# Altium schematic sheet Hall_sensors.SchDoc(Hall_sensors)
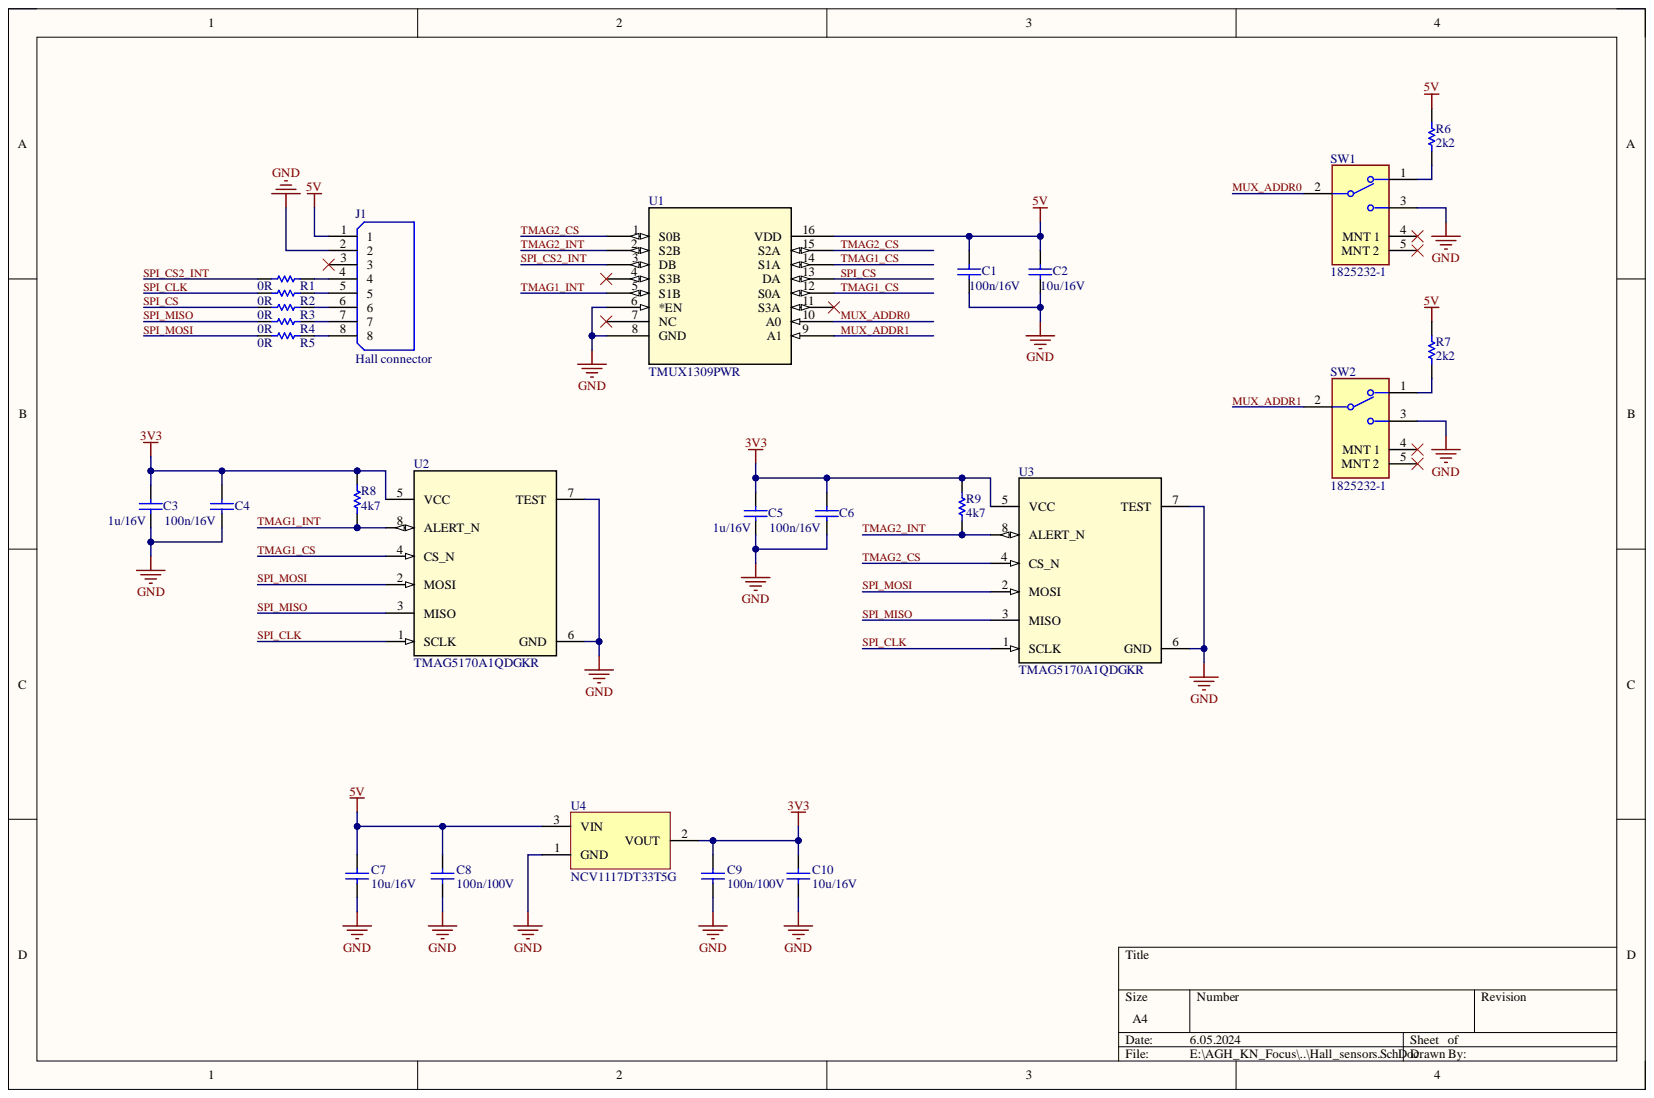
[diagram p0: Hall_sensors.SchDoc]

## Parts
C1  value 100n/16V  [CAP_0805N]  (hidden comment: 100n/100V/0805)
C2  value 10u/16V  [CAP_0805N]  (hidden comment: 10u/16V/0805)
C3  value 1u/16V  [CAP_0805N]  (hidden comment: 100n/100V/0805)
C4  value 100n/16V  [CAP_0805N]  (hidden comment: 10u/16V/0805)
C5  value 1u/16V  [CAP_0805N]  (hidden comment: 100n/100V/0805)
C6  value 100n/16V  [CAP_0805N]  (hidden comment: 10u/16V/0805)
C7  value 10u/16V  [CAP_0805N]  (hidden comment: 10u/16V/0805)
C8  value 100n/100V  [CAP_0805N]  (hidden comment: 100n/100V/0805)
C9  value 100n/100V  [CAP_0805N]  (hidden comment: 100n/100V/0805)
C10  value 10u/16V  [CAP_0805N]  (hidden comment: 10u/16V/0805)
J1  Hall connector  [AMPHENOL_10114830-11108LF]
R1  0R  [RESC1609X50X30NL8T20]
R2  0R  [RESC1609X50X30NL8T20]
R3  0R  [RESC1609X50X30NL8T20]
R4  0R  [RESC1609X50X30NL8T20]
R5  0R  [RESC1609X50X30NL8T20]
R6  2k2  [RESC2013X50X30LL20T20]
R7  2k2  [RESC2013X50X30LL20T20]
R8  4k7  [RESC2013X50X30LL20T20]
R9  4k7  [RESC2013X50X30LL20T20]
SW1  1825232-1  [FP-1825232-1-MFG]
SW2  1825232-1  [FP-1825232-1-MFG]
U1  TMUX1309PWR  [TSSOP_1308PWR_TEX]
U2  TMAG5170A1QDGKR  [DGK0008A-IPC_C]
U3  TMAG5170A1QDGKR  [DGK0008A-IPC_C]
U4  NCV1117DT33T5G  [NCV1117DT33T5G]

## Nets
3V3: C3.2 C4.1 C5.2 C6.1 C9.2 C10.2 R8.1 R9.1 U2.5(VCC) U3.5(VCC) U4.2
5V: C1.2 C2.1 C7.2 C8.2 J1.1 R6.1 R7.1 U1.16(VDD) U4.3
GND: C1.1 C2.2 C3.1 C4.2 C5.1 C6.2 C7.1 C8.1 C9.1 C10.1 J1.2 SW1.3 SW2.3 U1.6(*EN) U1.8(GND) U2.6(GND) U2.7(TEST) U3.6(GND) U3.7(TEST) U4.1
MUX_ADDR0: SW1.2 U1.10(A0)
MUX_ADDR1: SW2.2 U1.9(A1)
SPI_CLK: R2.1 U2.1(SCLK) U3.1(SCLK)
SPI_CS: R3.1 U1.13(DA)
SPI_CS2_INT: R1.1 U1.3(DB)
SPI_MISO: R4.1 U2.3(MISO) U3.3(MISO)
SPI_MOSI: R5.1 U2.2(MOSI) U3.2(MOSI)
TMAG1_CS: U1.12(S0A) U1.14(S1A) U2.4(CS_N)
TMAG1_INT: R8.2 U1.5(S1B) U2.8(ALERT_N)
TMAG2_CS: U1.1(S0B) U1.15(S2A) U3.4(CS_N)
TMAG2_INT: R9.2 U1.2(S2B) U3.8(ALERT_N)
NetJ1_4: J1.4 R1.2
NetJ1_5: J1.5 R2.2
NetJ1_6: J1.6 R3.2
NetJ1_7: J1.7 R4.2
NetJ1_8: J1.8 R5.2
NetR6_2: R6.2 SW1.1
NetR7_2: R7.2 SW2.1

Not connected: J1 3; SW1 4(MNT_1) 5(MNT_2); SW2 4(MNT_1) 5(MNT_2); U1 4(S3B) 7(NC) 11(S3A)

## Library data of the parts
C1 C3 C5 C8 C9 = CAP_MLCC/100n/100V/0805: Multilayer Ceramic Capacitors MLCC - SMD/SMT 100V 0.1uF X7R 0805 10%; Manufacturer: KEMET; Part Number: C0805C104J5RACTU; Component Kind: Standard; Distributor: Mouser; Distributor ID: 80-C0805C104J5R; Library Name: CAPACITOR.SchLib
C2 C4 C6 C7 C10 = CAP_MLCC/10u/16V/0805: Multilayer Ceramic Capacitors MLCC - SMD/SMT 10UF 16V 10% 0805; Manufacturer: Murata; Part Number: C0805C106K8PACTU; Component Kind: Standard; Distributor: Mouser; Distributor ID: 80-C0805C106K8P; Library Name: CAPACITOR.SchLib
J1 = 10114830-11108LF: 1.25mm Wire to Board Wafer, Right Angle, Surface Mount, 8 Positions; MF: Amphenol ICC; Availability: In Stock; Check_prices: https://www.snapeda.com/parts/10114830-11108LF/Amphenol+FCI/view-part/?ref=eda; Component Kind: Standard; Library Name: 10114830-11108LF.IntLib; MP: 10114830-11108LF; Package: None; Price: None; Purchase-URL: https://pricing.snapeda.com/search?q=10114830-11108LF&ref=eda; SnapEDA_Link: https://www.snapeda.com/parts/10114830-11108LF/Amphenol+FCI/view-part/?ref=snap
R1-R5 = CMP-2000-03816-5: Chip Resistor, 0 Ohm, Jumper, 100 mW, -55 to 155 degC, 0603 (1608 Metric), RoHS, Tape and Reel; Manufacturer: Vishay Semiconductor; Voltage Rating: 75 V; Component Kind: Standard; ComponentLink1Description: Manufacturer URL; ComponentLink1URL: http://www.vishay.com/; ComponentLink2Description: Datasheet; ComponentLink2URL: http://www.vishay.com/docs/20035/dcrcwe3.pdf; DatasheetVersion: 06/2012; Height: 0.45 mm; Length: 1.55 mm; Library Name: Altium Content Vault; Max Operating Temperature: 155 degC; Min Operating Temperature: -55 degC; Mount: Surface Mount; Mounting Technology: Surface Mount; Package Quantity: 5000; PackageDescription: 2-Pin Surface Mount Device, Body 1.575 x 0.85 mm; PackageReference: 0603; Packaging: Tape and Reel; Pins: 2; REACH SVHC: Unknown; RoHSCompliant: true
R6-R9 = CMP-2001-04896-1: 0805; Manufacturer: Vishay Dale; Part Number: CRCW08050000Z0EA; Component Kind: Standard; Library Name: Altium Content Vault
SW1 SW2 = CMP-03405-000001-1: SWITCH SLIDE SPDT 200MA 30V; Manufacturer: TE Connectivity; Contact Current Rating: 0.2A; Contact Resistance: 20mΩ; Electromechanical Life: 10000Cycles; Operating Force: 200gf; Automotive: No; Category: Switch; Component Kind: Standard; ComponentLink2Description: Datasheet; ComponentLink2URL: https://www.te.com/commerce/DocumentDelivery/DDEController?Action=showdoc&DocId=Customer+Drawing%7F1825232%7FB2%7Fpdf%7FEnglish%7FENG_CD_1825232_B2.pdf%7F1825232-1; Device Class L1: Electromechanical; Device Class L2: Switches; Device Class L3: Slide Switches; Digikey Description: SWITCH SLIDE SPDT 200MA 30V; Height: 3.7mm; Lead Free: Yes; Library Name: Altium Content Vault; Manufacturer Part Number: 1825232-1; Mount: Through Hole; Mouser Description: Slide Switches SLIDE SWITCH SPDT Right Angle; Octopart: https://octopart.com/1825232-1-te+connectivity-45209882; Package: 1825232-1; RoHS: TRUE; Temperature: -20°C to +70°C; Temperature Range High: +70°C; Temperature Range Low: -20°C; Throw Configuration: SPDT; Voltage Rating Dc: 30V
U1 = TMUX1309PWR: No Description Available; Mfr_Name: Texas Instruments; Component Kind: Standard; Library Name: TMUX1309PWR.SchLib; RefDes: RefDes; Type: Interface
U2 U3 = TMAG5170A1QDGKR: No Description Available; Mfr_Name: Texas Instruments; Component Kind: Standard; Library Name: TMAG5170A1QDGKR.SchLib; RefDes: RefDes; TYPE: TYPE
U4 = NCV1117DT33T5G: LDO 3.3V    NCV1117DT33T5G; Component Kind: Standard; Library Name: IC.SchLib
